# Altium schematic sheet Battery_ECU.SchDoc
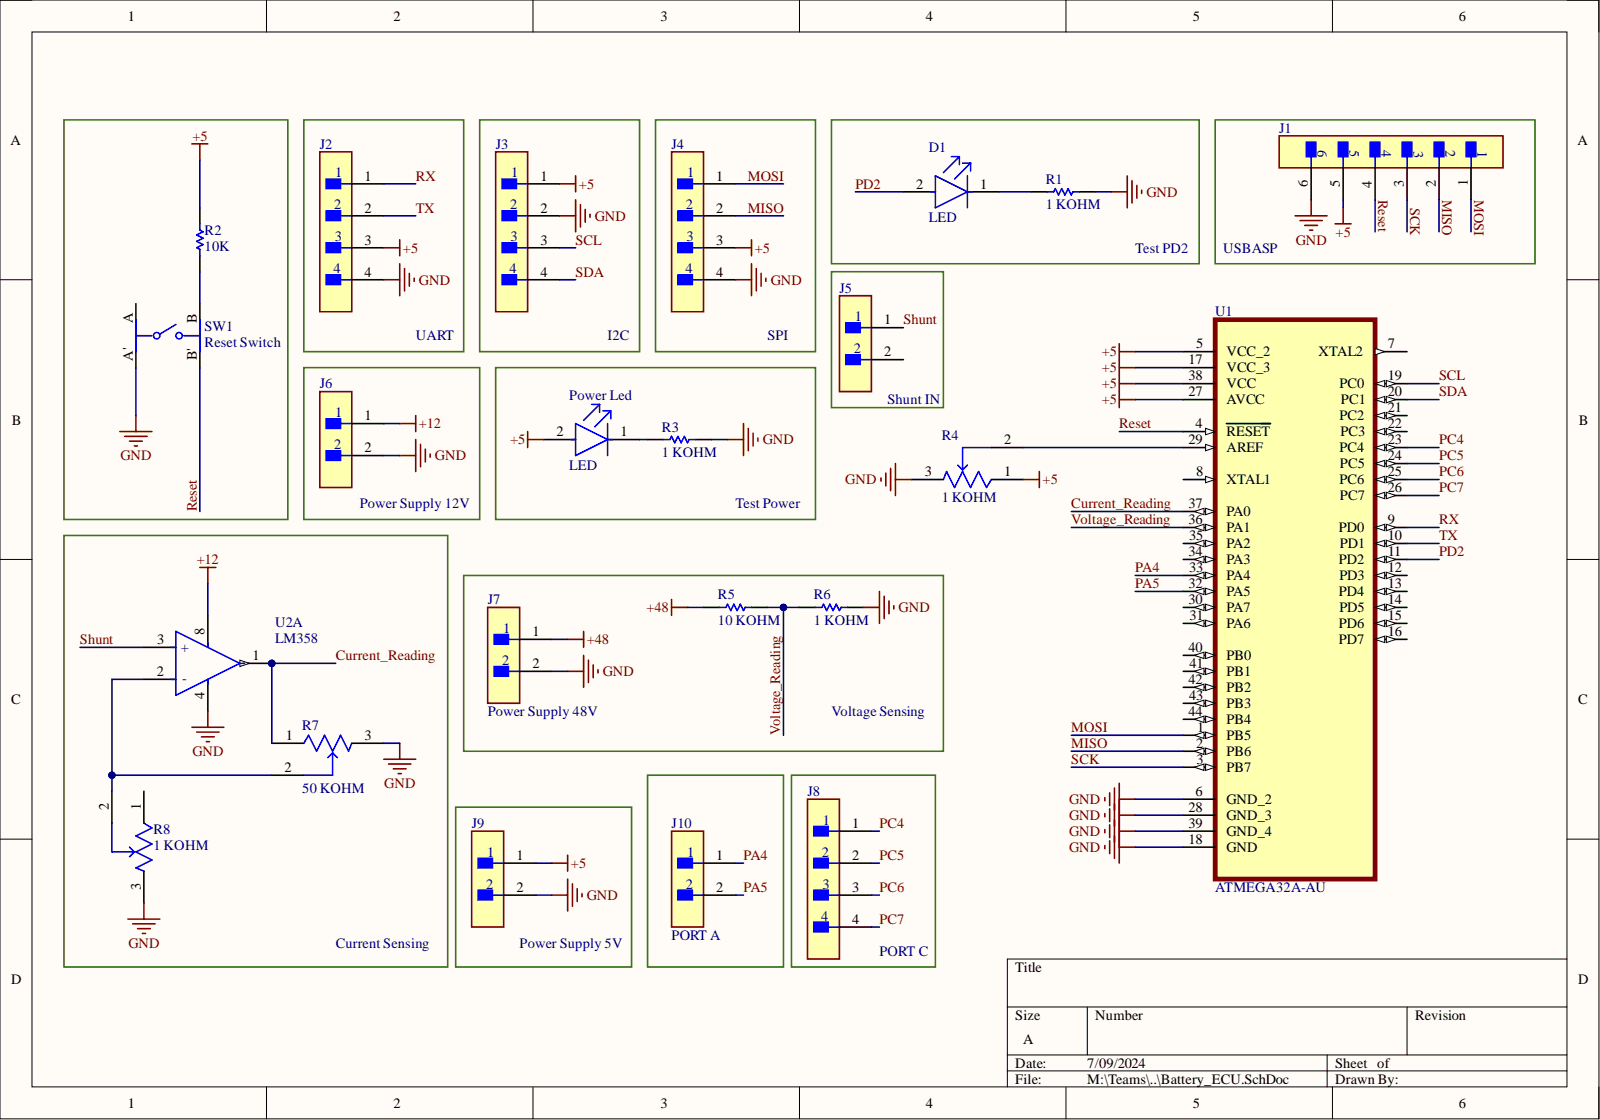
[diagram p0: Battery_ECU.SchDoc]

## Parts
D1  LED  [FP-LTST-C171GKT-MFG]
J1  USBASP  [FP-B6B-EH-A_LF_SN-MFG]
J2  UART  [FP-B04B-XASK-1_LF_SN-MFG]
J3  I2C  [FP-B04B-XASK-1_LF_SN-MFG]
J4  SPI  [FP-B04B-XASK-1_LF_SN-MFG]
J5  Shunt IN  [FP-B2B-XH-A_LF_SN-MFG]
J6  Power Supply 12V  [FP-B2B-XH-A_LF_SN-MFG]
J7  Power Supply 48V  [FP-B2B-XH-A_LF_SN-MFG]
J8  PORT C  [FP-B04B-XASK-1_LF_SN-MFG]
J9  Power Supply 5V  [FP-B2B-XH-A_LF_SN-MFG]
J10  PORT A  [FP-B2B-XH-A_LF_SN-MFG]
Power_Led  LED  [FP-LTST-C171GKT-MFG]
R1  1 KOHM  [RESC2013X60X35ML10T20]
R2  10K  [RESC2013X60X35ML10T20]
R3  1 KOHM  [RESC2013X60X35ML10T20]
R4  1 KOHM  [FP-3296W-MFG]
R5  10 KOHM  [RESC2013X60X35ML10T20]
R6  1 KOHM  [RESC2013X60X35ML10T20]
R7  50 KOHM  [FP-3296W-MFG]
R8  1 KOHM  [FP-3296W-MFG]
SW1  Reset Switch  [FP-EVQQ2Y02W-MFG]
U1  ATMEGA32A-AU  [QFP80P1200X1200X120-44N]
U2  LM358  [FP-751-07-IPC_C]  (part A)

## Nets
+5: J1.5 J2.3 J3.1 J4.3 J9.1 Power_Led.2 R2.2 R4.1 U1.5(VCC_2) U1.17(VCC_3) U1.27(AVCC) U1.38(VCC)
+12: J6.1 U2.8
+48: J7.1 R5.2
GND: J1.6 J2.4 J3.2 J4.4 J6.2 J7.2 J9.2 R1.2 R3.2 R4.3 R6.1 R7.3 R8.3 SW1.A'(A) U1.6(GND_2) U1.18(GND) U1.28(GND_3) U1.39(GND_4) U2.4
Current_Reading: R7.1 U1.37(PA0) U2.1
MISO: J1.2 J4.2 U1.2(PB6)
MOSI: J1.1 J4.1 U1.1(PB5)
PA4: J10.1 U1.33(PA4)
PA5: J10.2 U1.32(PA5)
PC4: J8.1 U1.23(PC4)
PC5: J8.2 U1.24(PC5)
PC6: J8.3 U1.25(PC6)
PC7: J8.4 U1.26(PC7)
PD2: D1.2 U1.11(PD2)
Reset: J1.4 SW1.B'(B) U1.4(RESET)
RX: J2.1 U1.9(PD0)
SCK: J1.3 U1.3(PB7)
SCL: J3.3 U1.19(PC0)
SDA: J3.4 U1.20(PC1)
Shunt: J5.1 U2.3(+)
TX: J2.2 U1.10(PD1)
Voltage_Reading: R5.1 R6.2 U1.36(PA1)
NetD1_1: D1.1 R1.1
NetPower Led_1: Power_Led.1 R3.1
NetR2_1: R2.1 SW1.B(B')
NetR4_2: R4.2 U1.29(AREF)
NetR7_2: R7.2 R8.2 U2.2(-)

Not connected: J5 2; R8 1; SW1 A(A'); U1 7(XTAL2) 8(XTAL1) 12(PD3) 13(PD4) 14(PD5) 15(PD6) 16(PD7) 21(PC2) 22(PC3) 30(PA7) 31(PA6) 34(PA3) 35(PA2) 40(PB0) 41(PB1) 42(PB2) 43(PB3) 44(PB4)

## Library data of the parts
D1 Power_Led = CMP-22017-000007-1: LED GREEN CLEAR CHIP SMD; Dominant Wavelength: 569nm; Forward Current: 30mA; Forward Voltage: 2.1V; Lens Color: Colorless; Lens Style: Diffused; Luminous Intensity: 12mcd; Number of Elements: 1; Power Dissipation: 100mW; Reverse Voltage: 5V; Test Current: 10mA; Viewing Angle: 130°; Wavelength: 585nm; Case Code (Imperial): 0805; Case Code (Metric): 2012; Case/Package: 0805; Color: Green; Component Kind: Standard; Depth: 1.25mm; Height: 0.8mm; Illumination Color: Green; Lead Free: Lead Free; Length: 2mm; Lens Transparency: Clear; Library Name: Altium Content Vault; Max Operating Temperature: 85°C; Min Operating Temperature: -55°C; Mount: Surface Mount; Number of LEDs: 1; Number of Pins: 2; Package Quantity: 1; Packaging: Tape and Reel; Power Rating: 100mW; Radiation Hardening: No; Width: 1.25mm
J1 = CMP-17439-000293-1: CONN HEADER VERT 6POS 2.5MM; Current Rating: 3A; Gender: Male; Mated Stacking Heights: 8.1mm; Number of Contacts: 6; Voltage Rating: 250V; Color: Natural; Component Kind: Standard; Connector Type: Header; Contact Finish - Mating: TIN; Contact Material: Brass; Contact Mating Length: 0.13inch; Contact Plating: Tin; Contact Shape: Square; Contact Type: Male Pin; Fastening Type: Friction; Flammability Rating: UL94 V-0; Housing Color: White; Insulation Color: Natural; Insulation Material: Nylon; Lead Free: Lead Free; Library Name: Altium Content Vault; Mount: Through Hole; Number of Positions: 6; Number of Rows: 1; Orientation: Straight; Packaging: Bulk; Pitch: 2.5mm; Series: EH; Termination: Solder
J2-J4 J8 = CMP-17439-000018-1: CONN HEADER VERT 4POS 2.5MM; Manufacturer: JST; Manufacturer Part Number: B04B-XASK-1(LF)(SN); Conn Gender: Male; Conn Orientation: Straight; Current Rating: 3A; Number Of Contacts: 4; Voltage Rating: 250V; Automotive: No; Category: Conn; Component Kind: Standard; ComponentLink2Description: Datasheet; ComponentLink2URL: http://www.jst-mfg.com/product/pdf/eng/eXA1.pdf; Contact Material: Tin,Copper; Device Class L1: Connectors; Device Class L2: Headers and Wire Housings; Device Class L3: unset; Digikey Description: CONN HEADER VERT 4POS 2.5MM; Height: 8.8mm; Lead Free: Yes; Library Name: Altium Content Vault; Number Of Rows: 1; Octopart: https://octopart.com/b04b-xask-1%28lf%29%28sn%29-jst-3990149; Package: B04B-XASK-1(LF)(SN); Pitch: 2.5mm; RoHS: TRUE; Temperature: -25°C to +85°C; Temperature Range High: +85°C; Temperature Range Low: -25°C
J5-J7 J9 J10 = CMP-2000-05888-3: CONN HEADER VERT 2POS 2.5MM; Connector Type: Male Pin; Contact Resistance: 20mR; Current Rating: 3A; Insulation Resistance: 1GR; Max Voltage Rating (AC): 250V; Number of Contacts: 2; Wire/Cable Gauge: 22AWG; Color: Natural; Component Kind: Standard; Contact Material: Brass; Contact Plating: Tin; Contact Type: Male Pin; Depth: 5.75mm; Fastening Type: Detent; Housing Color: White; Housing Material: Polyamide; Lead Free: Lead Free; Lead Pitch: 2.5mm; Length: 7.4mm; Library Name: Altium Content Vault; Max Current Rating: 3A; Max Operating Temperature: 85°C; Max Voltage Rating (DC): 250V; Min Operating Temperature: -25°C; Mount: Through Hole; Number of Positions: 2; Number of Rows: 1; Orientation: Straight; Packaging: Bulk; Pitch: 2.5mm; REACH SVHC: No SVHC; Series: XH; Termination: Solder; Voltage Rating: 250V; Voltage Rating (AC): 250V
R1-R3 R5 R6 = CMP-2100-03673-1: Chip Resistor, 0 Ohm, Jumper, +/- 5%, 0.125 W, -55 to 155 degC, 0805 (2012 Metric), RoHS, Tape and Reel; Manufacturer: Yageo; Power Rating: 125mW; Resistance: 0mOhm; Temperature Coefficient: 200ppm/degreesC; Voltage Rating: 150V; Case Code (Imperial): 0805; Case Code (Metric): 2012; Case/Package: 0805; Component Kind: Standard; Composition: ThickFilm; Contact Plating: Tin; Datasheet URL: http://www.yageo.com/NewPortal/yageodocoutput?fileName=/pdf/R-Chip/PYu-RC_Group_51_RoHS_L_5.pdf; Datasheet Version: Rev. 5, 10/2016; Depth: 1.25mm; Height: 0.5mm; Lead Free: LeadFree; Length: 2mm; Library Name: Altium Content Vault; Manufacturer URL: http://www.yageo.com/; Max Operating Temperature: 155degC; Military Standard: Not; Min Operating Temperature: -55degC; Number of Pins: 2; Package Description: 2-Pin Surface Mounted Device, Body 2 x 1.25 mm; Package Reference: 0805; Packaging: TapeandReel; Radiation Hardening: No; REACH SVHC: Unknown; RoHSCompliant: true; Termination: SMD/SMT; Tolerance: 5%; Voltage Rating (DC): 150V; Width: 1.25mm
R4 R7 R8 = CMP-2000-05583-2: TRIMMER 500K OHM 0.5W PC PIN TOP; Manufacturer: Bourns; Manufacturer Part Number: 3296W-1-504LF; Resistance: 500kΩ; Standoff Height: 0.38mm; Temperature Coefficient: 100ppm/°C; Tolerance: 10%; Value: 500kΩ; Voltage Rating: 300V; Automotive: No; Category: Res; Component Kind: Standard; ComponentLink2Description: Datasheet; ComponentLink2URL: https://www.bourns.com/docs/Product-Datasheets/3296.pdf; Device Class L1: Passive Components; Device Class L2: Resistors; Device Class L3: Variable Resistors and Potentiometers; Digikey Description: TRIMMER 500K OHM 0.5W PC PIN TOP; Height: 12.05mm; Lead Free: Yes; Library Name: Altium Content Vault; Mouser Description: Trimmer; Cermet; Rest 500 Kilohms; PCB; 25 Turns; Pwr-Rtg 0.5W; Octopart: https://octopart.com/3296w-1-504lf-bourns-143884; Package: 3296W; Power Rating: 0.5W; RoHS: TRUE; Series: Trimpot 3296-Sealed; Temperature: -55°C to +125°C; Temperature Range High: +125°C; Temperature Range Low: -55°C
SW1 = CMP-05618-000018-2: SWITCH TACTILE SPST-NO 0.02A 15V; NexarPartId: 47192941; Actuator Length: 700µm; Contact Current Rating: 20mA; Contact Resistance: 100mΩ; Electrical Life: 100kcycles; Illumination Type: Illuminated, Non-Illuminated; Number of Positions: 2; Operating Force: 3.5N; Switch Function: Off-Mom; Switch Travel: 250µm; Throw Configuration: SPST; Voltage Rating (AC): 250V; Case/Package: SMD/SMT; Color: White; Component Kind: Standard; Contact Plating: Silver; Current Rating: 20mA; Height: 2.5mm; Lead Free: Lead Free; Length: 6.5mm; Library Name: Altium Content Vault; Max Operating Temperature: 85°C; Max Voltage Rating (DC): 15V; Mechanical Life: 100kcycles; Min Operating Temperature: -40°C; Mount: Surface Mount; Orientation: Straight; Radiation Hardening: No; REACH SVHC: Unknown; RoHS: Compliant; Termination: SMD/SMT; Thickness: 1.8mm; Voltage Rating (DC): 15V; Width: 6mm
U1 = ATMEGA32A-AU: AVR AVRÂ® ATmega Microcontroller IC 8-Bit 16MHz 32KB (16K x 16) FLASH 44-TQFP (10x10); MF: Microchip; Check_prices: https://www.snapeda.com/parts/ATMEGA32A-AU/Microchip/view-part/?ref=eda; Component Kind: Standard; Library Name: ATMEGA32A-AU.IntLib; MP: ATMEGA32A-AU; Package: TQFP-44 Microchip; SnapEDA_Link: https://www.snapeda.com/parts/ATMEGA32A-AU/Microchip/view-part/?ref=snap
U2 = CMP-1310-00291-2: IC OPAMP GP 2 CIRCUIT 8SOIC; Bandwidth: 1MHz; Common Mode Rejection Ratio: 65dB; Dual Supply Voltage: 9V; Input Bias Current: 250nA; Input Offset Voltage (Vos): 7mV; Max Dual Supply Voltage: 16V; Max Supply Voltage: 32V; Min Dual Supply Voltage: 1.5V; Min Supply Voltage: 3V; Nominal Supply Current: 1.2mA; Number of Amplifiers: 2; Operating Supply Current: 1.5mA; Output Current: 40mA; Slew Rate: 0.6V/µs; Voltage Gain: 100dB; Case/Package: SOIC; Component Kind: Standard; Contact Plating: Tin; Gain Bandwidth Product: 1MHz; Halogen Free: Halogen Free; Height: 1.5mm; Lead Free: Lead Free; Length: 5mm; Library Name: Altium Content Vault; Max Operating Temperature: 70°C; Min Operating Temperature: 0°C; Number of Channels: 2; Number of Circuits: 2; Number of Elements: 2; Number of Pins: 8; Operating Supply Voltage: 16V; Output Current per Channel: 40mA; Package Quantity: 2500; Packaging: Tape and Reel; Power Supply Rejection Ratio (PSRR): 65dB; Radiation Hardening: No; REACH SVHC: No SVHC; Width: 4mm
